# Altium schematic sheet LIN_break.SchDoc
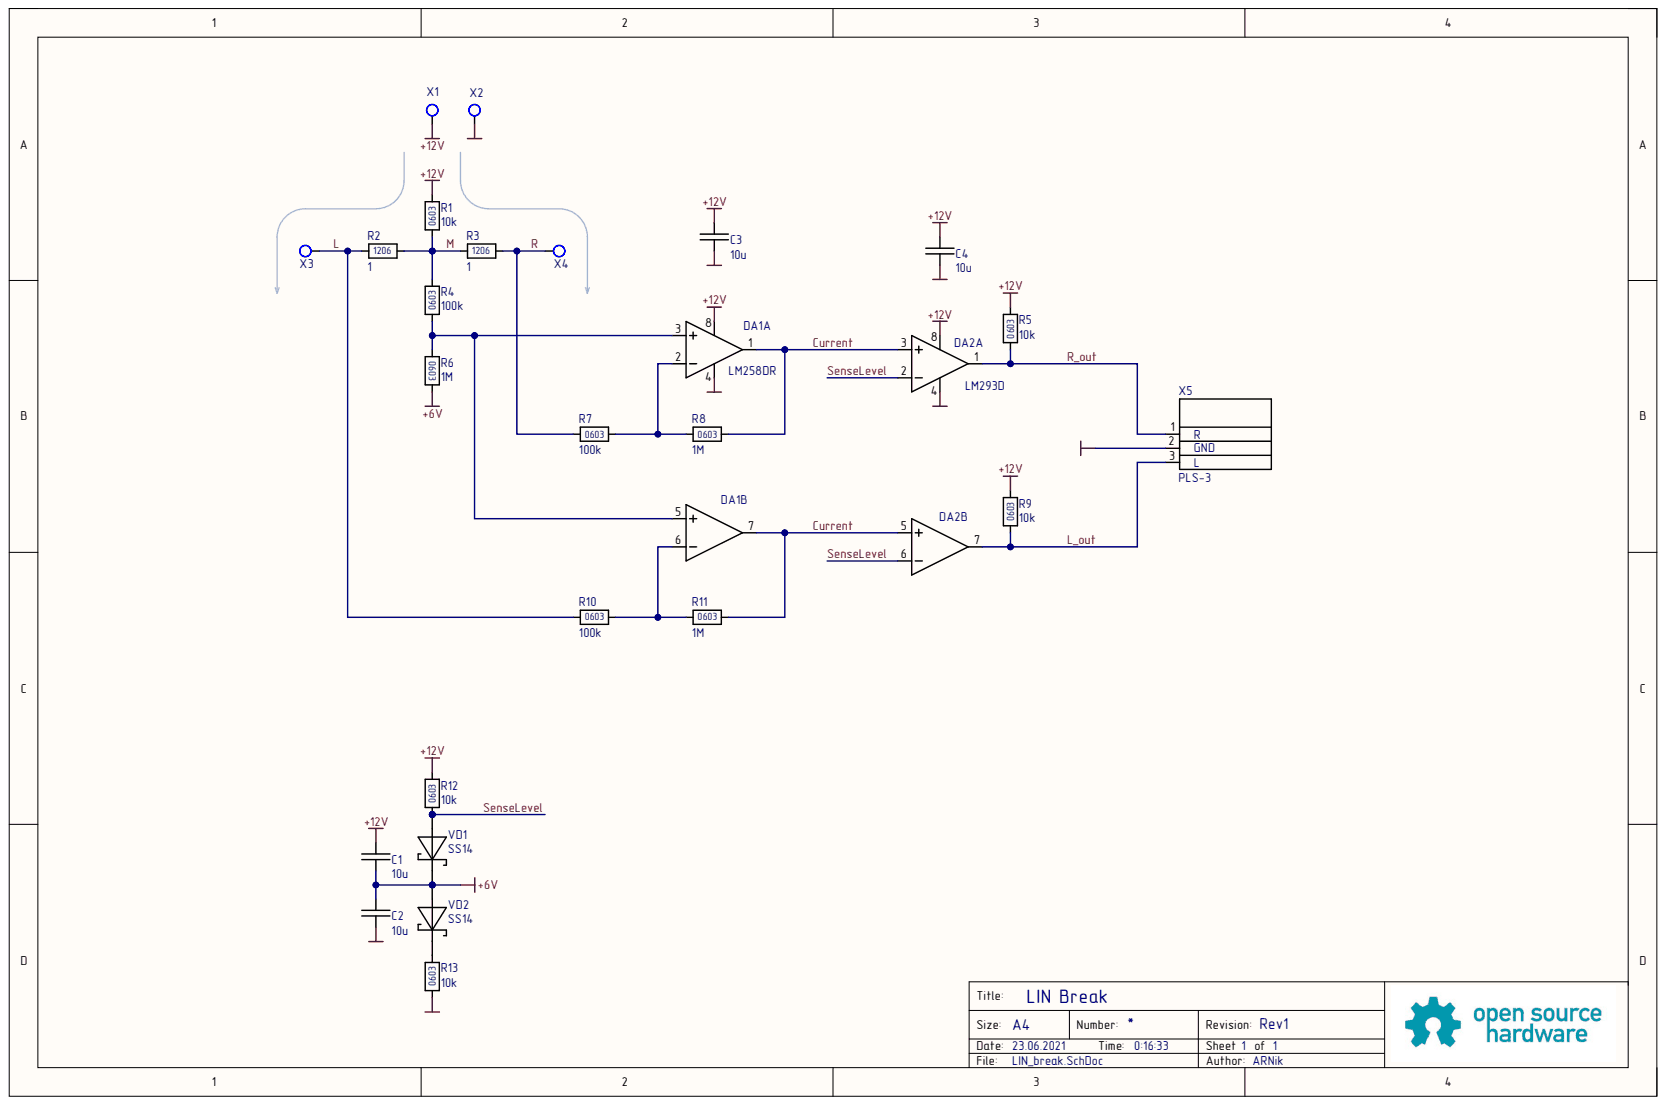
[diagram p0: LIN_break.SchDoc]

## Parts
C1  10u  [C0603]
C2  10u  [C0603]
C3  10u  [C0603]
C4  10u  [C0603]
DA1  LM258  [TI-SOIC8 D]  (parts A,B)
DA2  Comparator  [TI-SOIC8 D]  (value not drawn)  (parts A,B)
R1  10k  [R0603]
R2  1  [R1206]
R3  1  [R1206]
R4  100k  [R0603]
R5  10k  [R0603]
R6  1M  [R0603]
R7  100k  [R0603]
R8  1M  [R0603]
R9  10k  [R0603]
R10  100k  [R0603]
R11  1M  [R0603]
R12  10k  [R0603]
R13  10k  [R0603]
VD1  SS14  [DO-214AC(SMA)]
VD2  SS14  [DO-214AC(SMA)]
X1  1-pin_round NB  [Hole-2.4-5]  (value not drawn)  (no BOM)
X2  1-pin_round NB  [Hole-2.4-5]  (value not drawn)  (no BOM)
X3  1-pin_round NB  [Hole-2.4-5]  (value not drawn)  (no BOM)
X4  1-pin_round NB  [Hole-2.4-5]  (value not drawn)  (no BOM)
X5  PLS-3  [PLS-3]

## Nets
+6V: C1.2 C2.1 R6.2 VD1.1 VD2.2
+12V: C1.1 C3.1 C4.1 DA1.8 DA2.8 R1.2 R5.2 R9.2 R12.2 X1.1
GND: C2.2 C3.2 C4.2 DA1.4 DA2.4 R13.1 X2.1 X5.2
Current: DA1.1 DA1.7 DA2.3 DA2.5 R8.2 R11.2
L: R2.1 R10.1 X3.1
L_out: DA2.7 R9.1 X5.3
M: R1.1 R2.2 R3.1 R4.2
R: R3.2 R7.1 X4.1
R_out: DA2.1 R5.1 X5.1
SenseLevel: DA2.2 DA2.6 R12.1 VD1.2
NetDA1_2: DA1.2 R7.2 R8.1
NetDA1_3: DA1.3 DA1.5 R4.1 R6.1
NetDA1_6: DA1.6 R10.2 R11.1
NetR13_2: R13.2 VD2.1

## Library data of the parts
C1-C4 = C0603 10u 25V X5R 85°C ±20%: General  ceramic capacitor; Alt Part: C1608X5R106MFT(Darfon) C1608X5R1E106M080AC(TDK); Part Number: GRM188R61E106MA73#; Database Table Name: Capacitors-Murata; Dielectric: X5R; Tolerance: ±20%; Vendor: Murata; Voltage: 25V; Component Kind: Standard; Component Name: C0603 10u 25V X5R 85°C ±20%; HelpURL: https://psearch.en.murata.com/capacitor/result/smd/?pid=GRM188R61E106MA73#; Library Name: altium.DbLib; Package: C0603; Pins: 2; Temperature: 85°C; Value: 10u
DA1 = LM258DR: Op Amp; Database Table Name: ICS; Vendor: TI; Component Kind: Standard; Component Name: LM258DR; HelpURL: https://www.chipdip.ru/product/lm258d-ti; Library Name: altium.DbLib; Package: SOIC8; Part Number: LM258DR; Pins: 8; Value: LM258
DA2 = LM293D: Dual Comparator OK; Database Table Name: ICS; Value: LM293; Vendor: TI; Component Kind: Standard; Component Name: LM293D; HelpURL: https://www.ti.com/lit/ds/symlink/lm293.pdf; Library Name: altium.DbLib; Package: TSSOP8; Part Number: LM293D; Pins: 8; Price: 0.1
R1 R5 R9 R12 R13 = R0603 10k ±1% E24: Thick Film Chip Resistor - CR Series; Alt Part: RTT031002FTH (Ralec); Part Number: CR-03FL7---10k; Database Table Name: Resistors-Viking; Power: 1/10W; Tolerance: ±1%; Vendor: Viking; Voltage: 75V; Component Kind: Standard; Component Name: R0603 10k ±1% E24; HelpURL: https://www.viking.com.tw/en/search/index.html?f%5Bname_model%5D=CR-03FL7---10k&type=product; Library Name: altium.DbLib; Package: R0603; Pins: 2; Price: 0.001; Value: 10k
R2 R3 = R1206 1 1%: 1/4W 200V; Part Number: CR-06FL5---1R0; Database Table Name: Resistors; Vendor: Viking; Component Kind: Standard; Component Name: R1206 1 1%; HelpURL: https://www.viking.com.tw/en/category/Thick-Film-Resistor-CR..A-Series/Resistor-CR-A.html; Library Name: altium.DbLib; Package: R1206; Pins: 2; Power: 1/4W; Price: 0.0009; Value: 1
R4 R7 R10 = R0603 1M ±1% E24: Thick Film Chip Resistor - CR Series; Alt Part: RTT031004FTH (Ralec); Part Number: CR-03FL7----1M; Database Table Name: Resistors-Viking; Power: 1/10W; Tolerance: ±1%; Value: 1M; Vendor: Viking; Voltage: 75V; Component Kind: Standard; Component Name: R0603 1M ±1% E24; HelpURL: https://www.viking.com.tw/en/search/index.html?f%5Bname_model%5D=CR-03FL7----1M&type=product; Library Name: altium.DbLib; Package: R0603; Pins: 2; Price: 0.001
R6 R8 R11 = R0603 1M ±1% E24: Thick Film Chip Resistor - CR Series; Alt Part: RTT031004FTH (Ralec); Part Number: CR-03FL7----1M; Database Table Name: Resistors-Viking; Power: 1/10W; Tolerance: ±1%; Vendor: Viking; Voltage: 75V; Component Kind: Standard; Component Name: R0603 1M ±1% E24; HelpURL: https://www.viking.com.tw/en/search/index.html?f%5Bname_model%5D=CR-03FL7----1M&type=product; Library Name: altium.DbLib; Package: R0603; Pins: 2; Price: 0.001; Value: 1M
VD1 VD2 = SS14: 40V 1A Schottky diode; Vendor: ON; Component Kind: Standard; Component Name: SS14; Database Table Name: Diode; HelpURL: http://www.onsemi.com/pub/Collateral/SS19-D.pdf; Library Name: altium.DbLib; Package: DO-214AC(SMA); Part Number: SS14; Pins: 2; Price: 0.04; Value: SS14
X1-X4 = Hole-2.4-5: M2 x .4 Screw with Lock Washer; Database Table Name: Connectors; Component Name: Hole-2.4-5; Library Name: altium.DbLib; Pins: 1; Price: 0; Value: Hole-2.4-5
X5 = PLS-3: Database Table Name: Connectors; Component Kind: Standard; Component Name: PLS-3; Library Name: altium.DbLib; Part Number: PLS-3; Pins: 3; Value: PLS-3
